# Altium schematic sheet ICM-45686.SchDoc
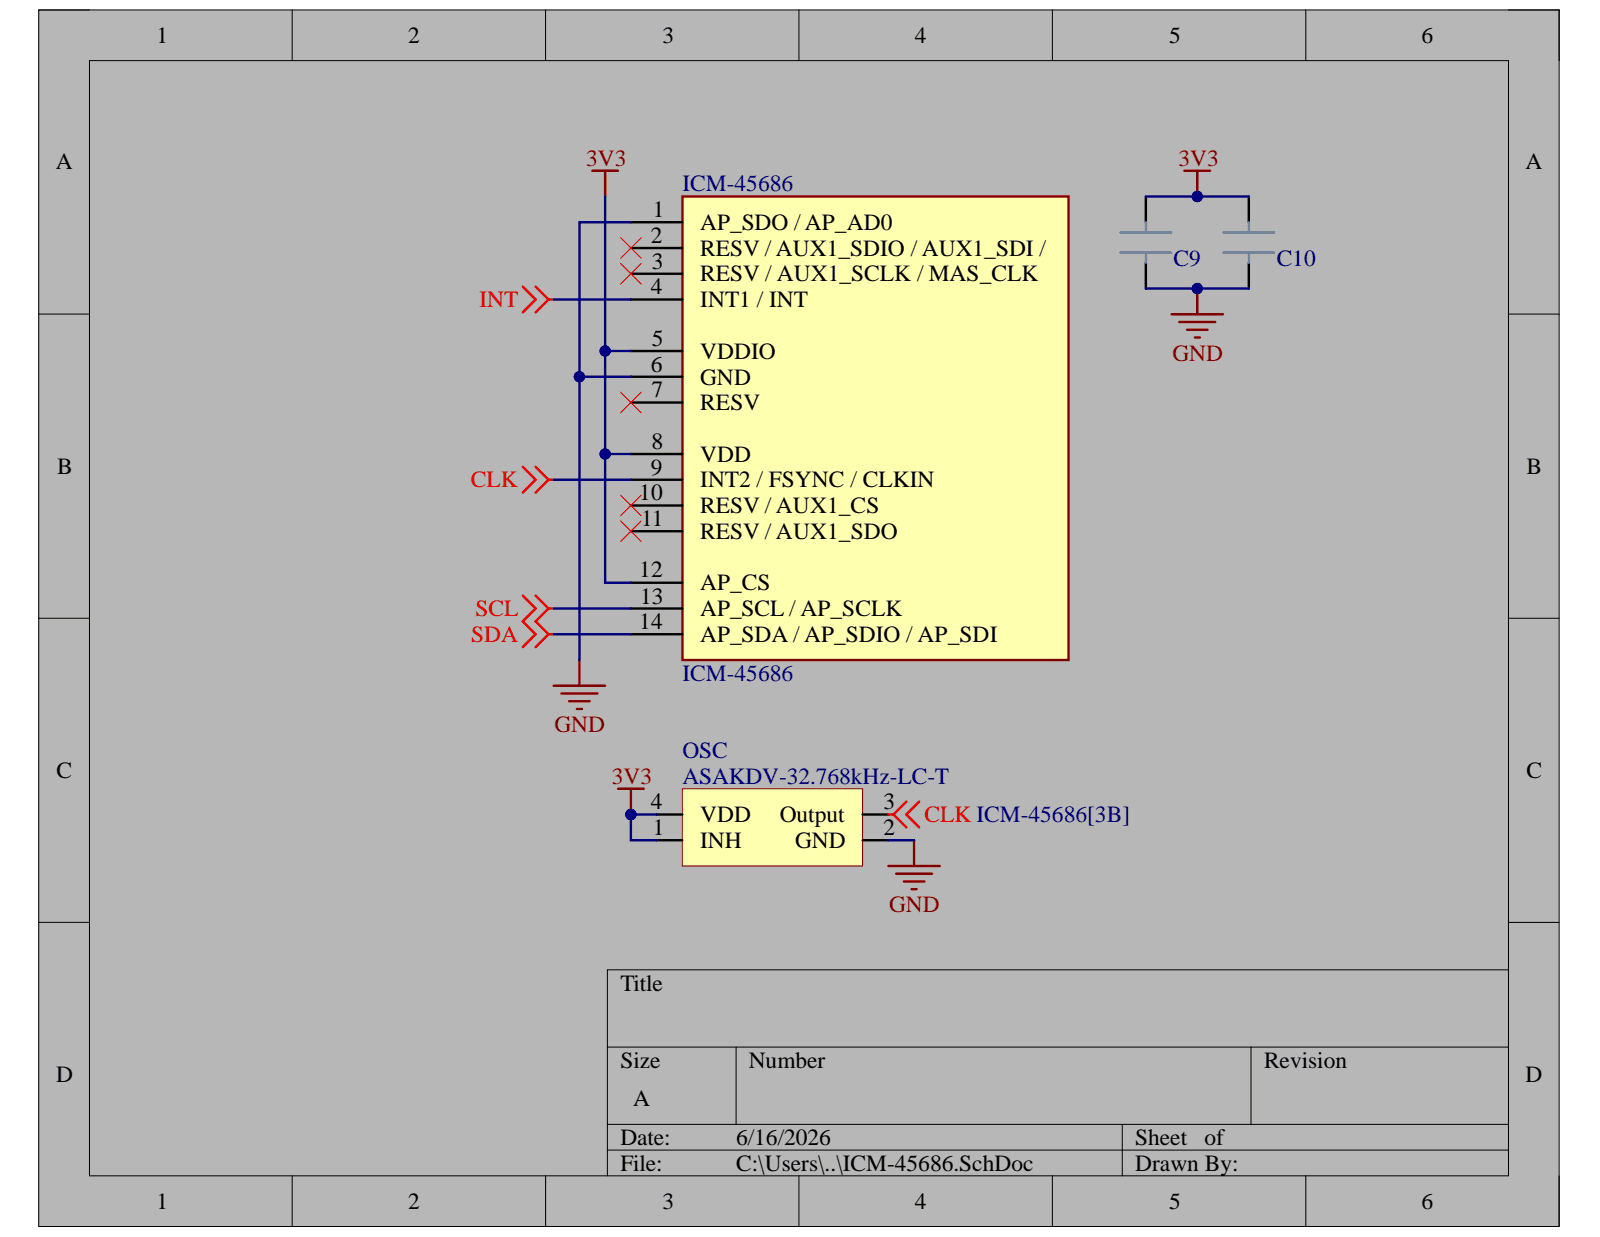
[diagram p0: ICM-45686.SchDoc]

## Parts
C9  10uF 0402  [0402 Cap]  (value not drawn)
C10  0.1uF 0402  [0402 Cap]  (value not drawn)
ICM-45686  ICM-45686  [ICM-45686]
OSC  ASAKDV-32.768kHz-LC-T  [ASAKDV-32.768kHz-LC-T]

## Nets
3V3: C9.2 C10.2 ICM-45686.5(VDDIO) ICM-45686.8(VDD) ICM-45686.12(AP_CS) OSC.1(INH) OSC.4(VDD)
CLK: ICM-45686.9(INT2_/_FSYNC_/_CLKIN) OSC.3(Output)
GND: C9.1 C10.1 ICM-45686.1(AP_SDO_/_AP_AD0) ICM-45686.6(GND) OSC.2(GND)
INT: ICM-45686.4(INT1_/_INT)
SCL: ICM-45686.13(AP_SCL_/_AP_SCLK)
SDA: ICM-45686.14(AP_SDA_/_AP_SDIO_/_AP_SDI)

Not connected: ICM-45686 2(RESV_/_AUX1_SDIO_/_AUX1_SDI_/) 3(RESV_/_AUX1_SCLK_/_MAS_CLK) 7(RESV) 10(RESV_/_AUX1_CS) 11(RESV_/_AUX1_SDO)

## Library data of the parts
C9 C10 = Cap_0402: Component Kind: Standard; Library Name: custom.SchLib
ICM-45686 = ICM-45686: ICM-45686 IMU; Manufacturer_Name: STMicroelectronics; Manufacturer_Part_Number: LSM6DSV16XTR; Arrow Part Number: LSM6DSV16XTR; Arrow Price/Stock: https://www.arrow.com/en/products/lsm6dsv16xtr/stmicroelectronics?utm_currency=USD&region=nac; Component Kind: Standard; Datasheet Link: https://www.st.com/resource/en/datasheet/lsm6dsv16x.pdf; Library Name: SamacSys.SchLib; Mouser Part Number: 511-LSM6DSV16XTR; Mouser Price/Stock: https://www.mouser.co.uk/ProductDetail/STMicroelectronics/LSM6DSV16XTR?qs=MyNHzdoqoQLCWe%2FE3pkKcA%3D%3D
OSC = ASAKDV-32.768kHz-LC-T: Abracon 32.768kHz oscillator.; Component Kind: Standard; Library Name: custom.SchLib
